# Altium schematic sheet Sheet2.SchDoc
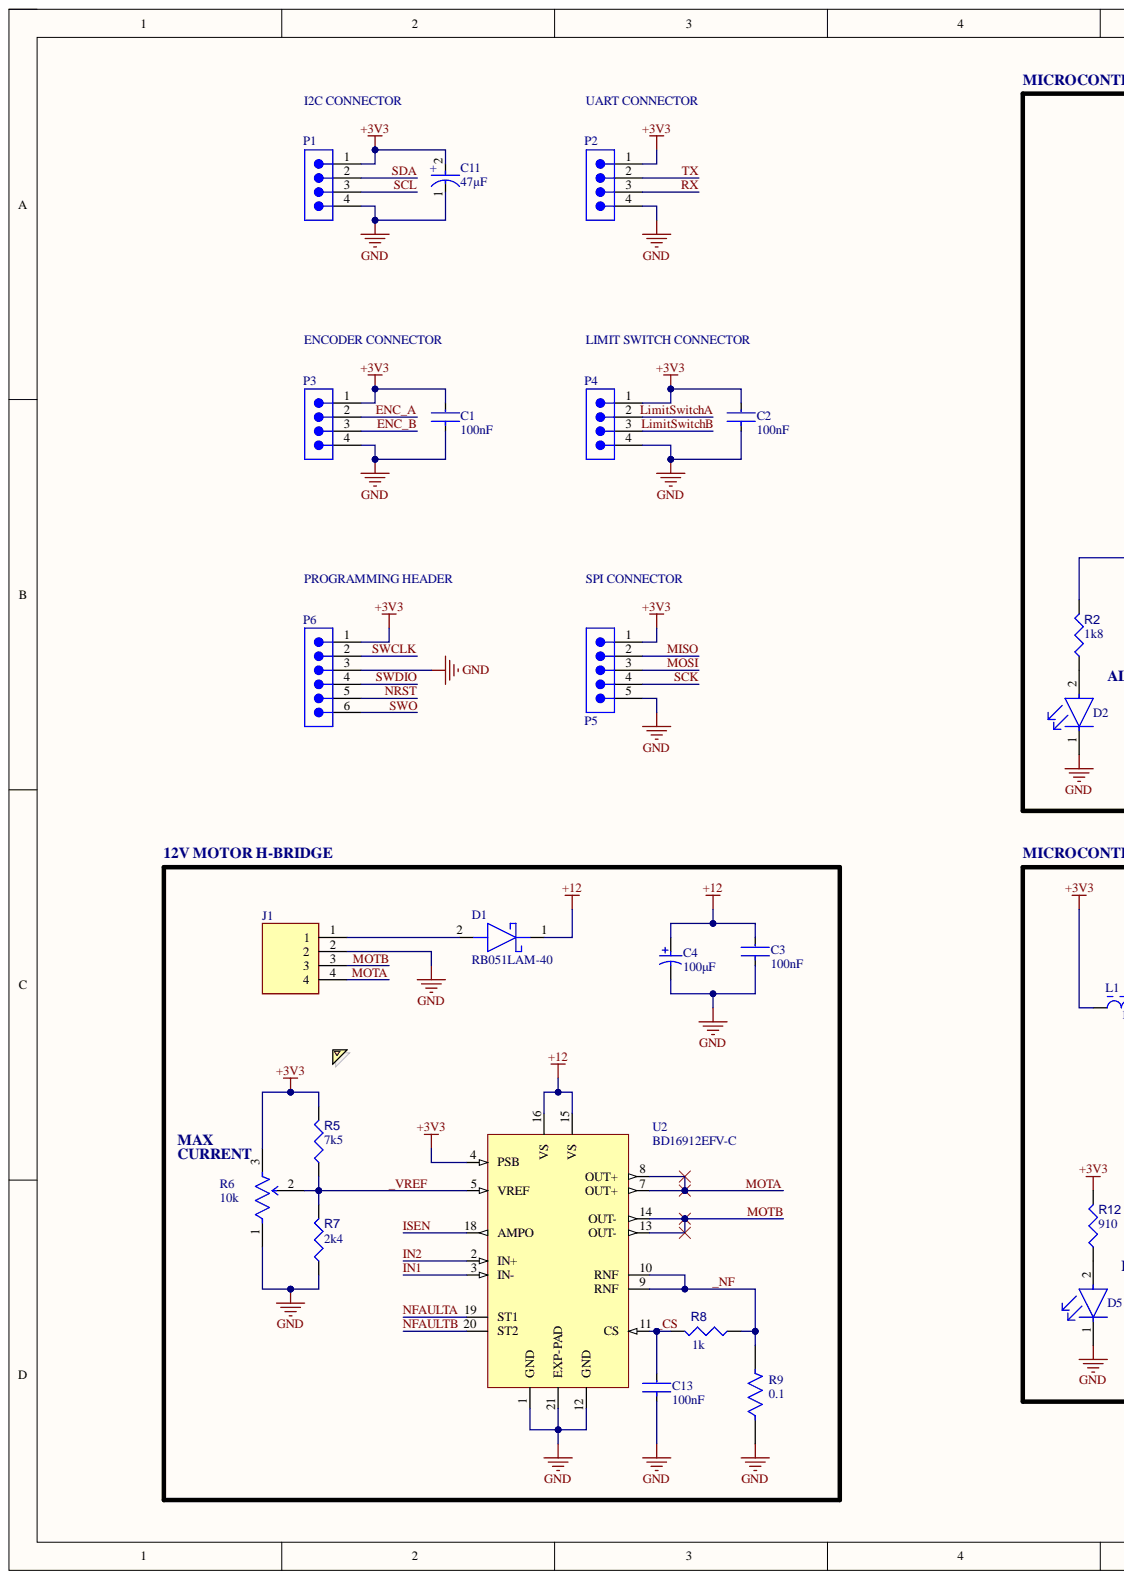
[diagram p0: Sheet2.SchDoc, left]
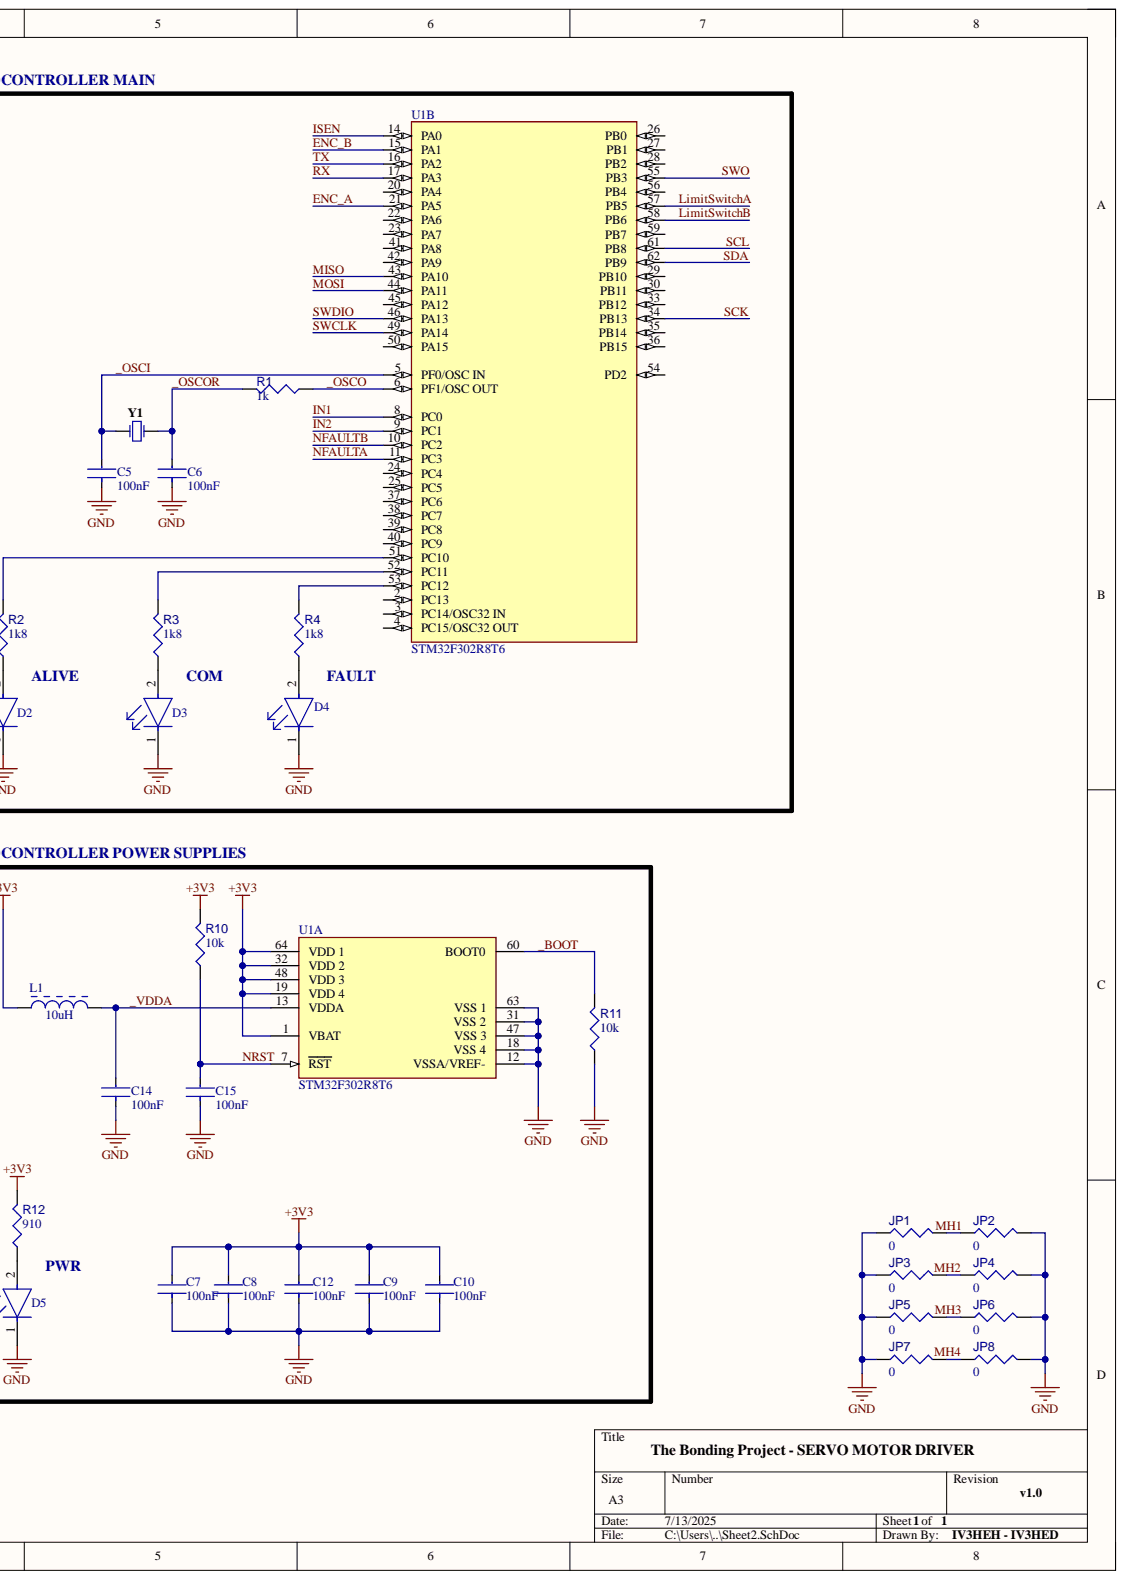
[diagram p1: Sheet2.SchDoc, right]

## Parts
C1  Capacitance: 100nF  [FP-0805-L_2_01_0_2-W_1_25-MFG]  (hidden comment: 08055C104K4T2A)
C2  Capacitance: 100nF  [FP-0805-L_2_01_0_2-W_1_25-MFG]  (hidden comment: 08055C104K4T2A)
C3  Capacitance: 100nF  [FP-0805-L_2_01_0_2-W_1_25-MFG]  (hidden comment: 08055C104K4T2A)
C4  Capacitance: 100μF  [CAPPR60-350-1150X800X1300]  (hidden comment: UPW1V101MPD)
C5  Capacitance: 100nF  [FP-0805-L_2_01_0_2-W_1_25-MFG]  (hidden comment: 08055C104K4T2A)
C6  Capacitance: 100nF  [FP-0805-L_2_01_0_2-W_1_25-MFG]  (hidden comment: 08055C104K4T2A)
C7  Capacitance: 100nF  [FP-0805-L_2_01_0_2-W_1_25-MFG]  (hidden comment: 08055C104K4T2A)
C8  Capacitance: 100nF  [FP-0805-L_2_01_0_2-W_1_25-MFG]  (hidden comment: 08055C104K4T2A)
C9  Capacitance: 100nF  [FP-0805-L_2_01_0_2-W_1_25-MFG]  (hidden comment: 08055C104K4T2A)
C10  Capacitance: 100nF  [FP-0805-L_2_01_0_2-W_1_25-MFG]  (hidden comment: 08055C104K4T2A)
C11  value 47µF  [FP-RAD-TH-D_6_3_0_5-L_7_1-MFG]  (hidden comment: EEA-GA1A470H)
C12  Capacitance: 100nF  [FP-0805-L_2_01_0_2-W_1_25-MFG]  (hidden comment: 08055C104K4T2A)
C13  Capacitance: 100nF  [FP-0805-L_2_01_0_2-W_1_25-MFG]  (hidden comment: 08055C104K4T2A)
C14  Capacitance: 100nF  [FP-0805-L_2_01_0_2-W_1_25-MFG]  (hidden comment: 08055C104K4T2A)
C15  Capacitance: 100nF  [FP-0805-L_2_01_0_2-W_1_25-MFG]  (hidden comment: 08055C104K4T2A)
D1  RB051LAM-40  [RF071LAM4S]
D2  LTST-C171GKT  [FP-LTST-C171GKT-MFG]  (value not drawn)
D3  LTST-C171GKT  [FP-LTST-C171GKT-MFG]  (value not drawn)
D4  LTST-C171GKT  [FP-LTST-C171GKT-MFG]  (value not drawn)
D5  LTST-C171GKT  [FP-LTST-C171GKT-MFG]  (value not drawn)
J1  1711042  [1711042]  (value not drawn)
JP1  value 0  [Copy of RESC0402(1005)_L]  (hidden comment: Jumper 0402)
JP2  value 0  [Copy of RESC0402(1005)_L]  (hidden comment: Jumper 0402)
JP3  value 0  [Copy of RESC0402(1005)_L]  (hidden comment: Jumper 0402)
JP4  value 0  [Copy of RESC0402(1005)_L]  (hidden comment: Jumper 0402)
JP5  value 0  [Copy of RESC0402(1005)_L]  (hidden comment: Jumper 0402)
JP6  value 0  [Copy of RESC0402(1005)_L]  (hidden comment: Jumper 0402)
JP7  value 0  [Copy of RESC0402(1005)_L]  (hidden comment: Jumper 0402)
JP8  value 0  [Copy of RESC0402(1005)_L]  (hidden comment: Jumper 0402)
L1  10uH  [INDC2013X105X50ML20T20]
P1  61300411121  [61300411121]  (value not drawn)
P2  61300411121  [61300411121]  (value not drawn)
P3  61300411121  [61300411121]  (value not drawn)
P4  61300411121  [61300411121]  (value not drawn)
P5  61300511121  [61300511121]  (value not drawn)
P6  61300611121  [61300611121]  (value not drawn)
R1  value 1k  [RESC0805(2012)_L]  (hidden comment: Resistor 1K +/-5% 0805 125 mW)
R2  value 1k8  [RESC0805(2012)_L]  (hidden comment: Resistor 1K +/-5% 0805 125 mW)
R3  value 1k8  [RESC0805(2012)_L]  (hidden comment: Resistor 1K +/-5% 0805 125 mW)
R4  value 1k8  [RESC0805(2012)_L]  (hidden comment: Resistor 1K +/-5% 0805 125 mW)
R5  value 7k5  [RESC0805(2012)_L]  (hidden comment: Resistor 1K +/-5% 0805 125 mW)
R6  Resistance: 10k  [FP-ST-4EB-MFG]  (hidden comment: ST-4ETB204)
R7  value 2k4  [RESC0805(2012)_L]  (hidden comment: Resistor 1K +/-5% 0805 125 mW)
R8  value 1k  [RESC0805(2012)_L]  (hidden comment: Resistor 1K +/-5% 0805 125 mW)
R9  value 0.1  [FP-RL2512-MFG]  (hidden comment: CMP-03412-013102-1)
R10  value 10k  [RESC0805(2012)_L]  (hidden comment: Resistor 1K +/-5% 0805 125 mW)
R11  value 10k  [RESC0805(2012)_L]  (hidden comment: Resistor 1K +/-5% 0805 125 mW)
R12  value 910  [RESC0805(2012)_L]  (hidden comment: Resistor 1K +/-5% 0805 125 mW)
U1  STM32F302R8T6  [FP-LQFP64-5W-IPC_A]  (parts A,B)
U2  BD16912EFV-C  [HTSSOP-B20]
Y1  XRCGB16M000FXN00R0  [XRCGB16M000FXN00R0]  (value not drawn)

## Nets
+3V3: C1.2 C2.2 C7.2 C8.2 C9.2 C10.2 C11.2 C12.2 L1.1 P1.1 P2.1 P3.1 P4.1 P5.1 P6.1 R5.2 R6.3 R10.2 R12.2 U1.1(VBAT) U1.19(VDD_4) U1.32(VDD_2) U1.48(VDD_3) U1.64(VDD_1) U2.4(PSB)
+12: C3.2 C4.1 D1.1 U2.15(VS) U2.16(VS)
GND: C1.1 C2.1 C3.1 C4.2 C5.1 C6.1 C7.1 C8.1 C9.1 C10.1 C11.1 C12.1 C13.1 C14.1 C15.1 D2.1 D3.1 D4.1 D5.1 J1.2 JP1.1 JP2.2 JP3.1 JP4.2 JP5.1 JP6.2 JP7.1 JP8.2 P1.4 P2.4 P3.4 P4.4 P5.5 P6.3 R6.1 R7.1 R9.1 R11.1 U1.12(VSSA/VREF-) U1.18(VSS_4) U1.31(VSS_2) U1.47(VSS_3) U1.63(VSS_1) U2.1(GND) U2.12(GND) U2.21(EXP-PAD)
_BOOT: R11.2 U1.60(BOOT0)
_CS: C13.2 R8.2 U2.11(CS)
_NF: R8.1 R9.2 U2.9(RNF) U2.10(RNF)
_OSCI: C5.2 U1.5(PF0/OSC_IN) Y1.1
_OSCO: R1.1 U1.6(PF1/OSC_OUT)
_OSCOR: C6.2 R1.2 Y1.2
_VDDA: C14.2 L1.2 U1.13(VDDA)
_VREF: R5.1 R6.2 R7.2 U2.5(VREF)
ENC_A: P3.2 U1.21(PA5)
ENC_B: P3.3 U1.15(PA1)
IN1: U1.8(PC0) U2.3(IN-)
IN2: U1.9(PC1) U2.2(IN+)
ISEN: U1.14(PA0) U2.18(AMPO)
LimitSwitchA: P4.2 U1.57(PB5)
LimitSwitchB: P4.3 U1.58(PB6)
MH1: JP1.2 JP2.1
MH2: JP3.2 JP4.1
MH3: JP5.2 JP6.1
MH4: JP7.2 JP8.1
MISO: P5.2 U1.43(PA10)
MOSI: P5.3 U1.44(PA11)
MOTA: J1.4 U2.7(OUT+) U2.8(OUT+)
MOTB: J1.3 U2.13(OUT-) U2.14(OUT-)
NFAULTA: U1.11(PC3) U2.19(ST1)
NFAULTB: U1.10(PC2) U2.20(ST2)
NRST: C15.2 P6.5 R10.1 U1.7(RST)
RX: P2.3 U1.17(PA3)
SCK: P5.4 U1.34(PB13)
SCL: P1.3 U1.61(PB8)
SDA: P1.2 U1.62(PB9)
SWCLK: P6.2 U1.49(PA14)
SWDIO: P6.4 U1.46(PA13)
SWO: P6.6 U1.55(PB3)
TX: P2.2 U1.16(PA2)
NetD1_2: D1.2 J1.1
NetD2_2: D2.2 R2.1
NetD3_2: D3.2 R3.1
NetD4_2: D4.2 R4.1
NetD5_2: D5.2 R12.1
NetR2_2: R2.2 U1.51(PC10)
NetR3_2: R3.2 U1.52(PC11)
NetR4_2: R4.2 U1.53(PC12)

Not connected: U1 2(PC13) 3(PC14/OSC32_IN) 4(PC15/OSC32_OUT) 20(PA4) 22(PA6) 23(PA7) 24(PC4) 25(PC5) 26(PB0) 27(PB1) 28(PB2) 29(PB10) 30(PB11) 33(PB12) 35(PB14) 36(PB15) 37(PC6) 38(PC7) 39(PC8) 40(PC9) 41(PA8) 42(PA9) 45(PA12) 50(PA15) 54(PD2) 56(PB4) 59(PB7)

## Library data of the parts
C1-C3 C5-C10 C12-C15 = CMP-2007-04370-2: Automotive Ceramic Capacitor, 0805, 100nF, 10%, X7R, 15%, 50V; Case Code (Imperial): 0805; Case Code (Metric): 2012; Case/Package: 0805; Component Kind: Standard; Construction: Flat; Contact Plating: Tin; Depth: 1.25mm; Dielectric: X7R; Height: 0.94mm; Lead Free: Lead Free; Length: 2.01mm; Library Name: Altium Content Vault; Max Operating Temperature: 125°C; Min Operating Temperature: -55°C; Mount: Surface Mount; Number of Pins: 2; Package Quantity: 1; Packaging: Tape and Reel; Radiation Hardening: No; Ratings: AEC-Q200; REACH SVHC: No SVHC; RoHS Compliant: Yes; Series: -; Termination: SMD/SMT; Thickness: 0.037inch; Tolerance: 10%; Voltage Rating: 50V; Voltage Rating (DC): 50V; Width: 0.049inch
C4 = CMP-2000-07363-1: Aluminum Electrolytic Capacitor, 100 uF, +/- 20%, 35 V, -55 to 105 degC, 2-Pin THD, RoHS, Bulk; Manufacturer: Nichicon; Brand/Series: UPWSeries; Configuration: Radial,Can; Temperature, Operating, Minimum: -55Deg.C; Tolerance: -20,+20%; Voltage, Rating: 35VDC; Case Size: 0.315In.Dia.x0.453In.L(8mmDia.x11.5mmL); Component Kind: Standard; Datasheet URL: http://nichicon-us.com/english/products/pdfs/e-upw.pdf; Datasheet Version: 08/2015; Lead Spacing: 0.138In.(3.50mm)mm; Library Name: Altium Content Vault; Manufacturer URL: http://www.nichicon.co.jp/english/; Material, Element: AluminumElectrolytic; Mounting Technology: ThroughHole; Mounting Type: PCB; Package Description: 2-Pin Through Hole Device, Dia 8 mm, Body Length 11.5 mm; Package Reference: CAPPR60-350-1150X800X1300; Termination: Through-hole
C11 = CMP-00001-1: Aluminum Electrolytic Capacitor, Polarized, Aluminum (wet), 10V, 20% +Tol, 20% -Tol, 47uF, Through Hole Mount; Capacitor Type: Aluminium; Leakage Current: 4.7uA; Ripple Current: 65mA; Tan Delta: 0.22; Component Kind: Standard; Diameter: 6.3mm; Dielectric Material: Aluminium; JESD-609 Code: e3; Length: 7mm; Max Operating Temperature: 105°C; Min Operating Temperature: -55°C; Mounting Technology: Through Hole; Package Shape: Cylindrical; Package Style: RadialMeter; Packaging: Tape and Reel; Pins: 2; Polarity: Polarized; Rated DC Voltage (URdc): 10V; Reach SVHC Compliant: Yes; RoHS Compliant: Yes; Terminal Finish: Tin; Terminal Pitch: 2.5mm; Termination: Wire; Tolerance  (fill in as +-): 20%; Tolerance (fill in as +-): 20%; Library Name: Massimo Danelutti
D1 = RB051LAM-40: Schottky Diode; Manufacturer_Name: ROHM Semiconductor; Component Kind: Standard; Datasheet Link: https://fscdn.rohm.com/en/products/databook/datasheet/discrete/diode/schottky_barrier/rb051lam-40tr-e.pdf; Height: 0.95mm; Manufacturer_Part_Number: RB051LAM-40; Mouser Part Number: N/A; Mouser Price/Stock: https://www.mouser.co.uk/ProductDetail/ROHM-Semiconductor/RB051LAM-40?qs=W0yvOO0ixfF7GPscPw9n5Q%3D%3D
D2-D5 = CMP-22017-000007-1: LED GREEN CLEAR CHIP SMD; Dominant Wavelength: 569nm; Forward Current: 30mA; Forward Voltage: 2.1V; Lens Color: Colorless; Lens Style: Diffused; Luminous Intensity: 12mcd; Number of Elements: 1; Power Dissipation: 100mW; Reverse Voltage: 5V; Test Current: 10mA; Viewing Angle: 130°; Wavelength: 585nm; Case Code (Imperial): 0805; Case Code (Metric): 2012; Case/Package: 0805; Color: Green; Component Kind: Standard; Depth: 1.25mm; Height: 0.8mm; Illumination Color: Green; Lead Free: Lead Free; Length: 2mm; Lens Transparency: Clear; Max Operating Temperature: 85°C; Min Operating Temperature: -55°C; Mount: Surface Mount; Number of LEDs: 1; Number of Pins: 2; Package Quantity: 1; Packaging: Tape and Reel; Power Rating: 100mW; Radiation Hardening: No; Width: 1.25mm
  D2 D4 D5: Library Name: Altium Content Vault
  D3: Library Name: Bonding_Motor_Driver.IntLib
J1 = 1711042: Connector; Manufacturer_Name: Phoenix Contact; Arrow Part Number: 1711042; Arrow Price/Stock: https://www.arrow.com/en/products/1711042/phoenix-contact?utm_currency=USD&region=nac; Component Kind: Standard; Datasheet Link: http://www.phoenixcontact.com/de/produkte/1711042/pdf; Height: 18.2mm; Library Name: SamacSys.SchLib; Manufacturer_Part_Number: 1711042; Mouser Part Number: 651-1711042; Mouser Price/Stock: https://www.mouser.co.uk/ProductDetail/Phoenix-Contact/1711042?qs=JcjeNNoqGSOUKx8wK4Z%252B%2Fg%3D%3D
JP1-JP8 = CMP-009-00148-4: Case/Package: 0402; Component Kind: Standard; Description: Jumper 0402; Max Operating Temperature: 155°C; Min Operating Temperature: -55°C; Pins: 2; Library Name: Massimo Danelutti
L1 = CMP-006-00000-3: IND, Chip Ferrite Bead, 330 Ohm, +/- 25%, 1.5 A, -55 to 125 degC, 0805 (2012 Metric), Tape and Reel; Manufacturer: Murata; Current Rating: 1.5A; Impedance: 330Ohm; Resistance: 90mohm; Case/Package: 0805; Component Kind: Standard; Core Material: Ferrite; Datasheet URL: http://psearch.en.murata.com/inductor/product/LQG15HS15NJ02_.pdf; Datasheet Version: Nov-11; Max Current Rating: 1.5A; Max Operating Temperature: 125°C; Min Operating Temperature: -55°C; Mounting Technology: SM; Package Description: 2-Pin Surface Mount Device, Body 2 x1.25 mm; Package Quantity: 4000; Package Reference: 0805; Packaging: TapeandReel; RoHS Compliant: True; Tolerance: 25%; Value: 10uH; Library Name: Massimo Danelutti
P1-P4 = CMP-1502-01064-3: NexarPartId: 32855522; Connector Type: Male Pin; Contact Resistance: 20mΩ; Current Rating: 3A; Gender: Male; Number of Contacts: 4; Operating Supply Voltage: 250V; Pitch: 2.54mm; Color: Black; Component Kind: Standard; Contact Material: Copper; Contact Mating Length: 5.9944mm; Contact Plating: Gold; Height: 8.89mm; Lead Free: Lead Free; Lead Pitch: 2.54mm; Library Name: Altium Content Vault; Max Operating Temperature: 105°C; Min Operating Temperature: -40°C; Mount: Through Hole; Number of Pins: 4; Number of Positions: 4; Number of Rows: 1; Orientation: Vertical; Packaging: Bulk; REACH SVHC: Unknown; RoHS: Compliant; Termination: Solder; Voltage Rating: 250V
P5 = CMP-1502-01068-3: NexarPartId: 32855526; Connector Type: Male Pin; Contact Resistance: 20mΩ; Current Rating: 3A; Gender: Male; Number of Contacts: 5; Operating Supply Voltage: 250V; Pitch: 2.54mm; Color: Black; Component Kind: Standard; Contact Material: Copper; Contact Mating Length: 5.9944mm; Contact Plating: Gold; Height: 8.89mm; Lead Free: Lead Free; Lead Pitch: 2.54mm; Library Name: Altium Content Vault; Max Operating Temperature: 105°C; Min Operating Temperature: -40°C; Mount: Through Hole; Number of Pins: 5; Number of Positions: 5; Number of Rows: 1; Orientation: Vertical; Packaging: Bulk; REACH SVHC: Unknown; RoHS: Compliant; Termination: Solder; Voltage Rating: 250V
P6 = CMP-1502-01070-3: NexarPartId: 20084590; Connector Type: Male Pin; Contact Resistance: 20mΩ; Current Rating: 3A; Gender: Male; Number of Contacts: 6; Operating Supply Voltage: 250V; Pitch: 2.54mm; Color: Black; Component Kind: Standard; Contact Gender: Male; Contact Material: Copper; Contact Mating Length: 5.9944mm; Contact Plating: Gold; Height: 8.89mm; Housing Material: PBT; Lead Free: Lead Free; Lead Pitch: 2.54mm; Library Name: Altium Content Vault; Max Operating Temperature: 105°C; Min Operating Temperature: -40°C; Mount: Through Hole; Number of Pins: 6; Number of Positions: 6; Number of Rows: 1; Orientation: Vertical; Packaging: Bulk; REACH SVHC: Unknown; RoHS: Compliant; Termination: Through Hole; Voltage Rating: 250V
R1-R5 R7 R8 R10-R12 = CMP-009-00118-3: Chip Resistor, 1 kOhms, +/-5%, 125 mW, -55 to 155 degC, 0805; Power: 125mW; Tolerance: 5%; Case/Package: 0805; Component Kind: Standard; Max Operating Temperature: 155°C; Min Operating Temperature: -55°C; Pins: 2; Library Name: Massimo Danelutti
R6 = CMP-71554-000002-1: TRIMMER 200K OHM 0.25W GW TOP; Max Power Dissipation: 250mW; Number of Turns: 1; Temperature Coefficient: 100ppm/°C; Tolerance: 20%; Color: Black; Component Kind: Standard; Composition: Cermet; Height: 0.091inch; Lead Free: Lead Free; Length: 0.197inch; Library Name: Altium Content Vault; Max Operating Temperature: 125°C; Min Operating Temperature: -55°C; Mount: Surface Mount; Package Quantity: 1; Packaging: Tape and Reel; Radiation Hardening: No; Termination: Gull Wing; Weight: 120mg; Width: 0.177inch
R9 = CMP-009-00181-1: RES 0.1 OHM 1% 1W 2512; Manufacturer: YAGEO; Manufacturer Part Number: RL2512FK-070R1L; Automotive Grade: Grade 0; Temperature Coefficient: 600ppm/°C; Automotive: Yes; Case/Package: RL2512; Category: Res; Component Kind: Standard; Component Type: Standard; Composition: Thick Film; Design Item ID: CMP-03412-013102-1; Device Class L1: Passive Components; Device Class L2: Resistors; Device Class L3: Chip SMD Resistors; Digikey Description: RES 0.1 OHM 1% 1W 2512; Footprint Url: http://www.yageo.com/exep/pages/download/literatures/PYu-R_Mount_10.pdf; Height: 0.65mm; Ipc Land Pattern Name: RESC635320X55; Lead Free: Yes; Mouser Description: Current Sense Resistors 0.1ohm 1% 1W; Octopart: https://octopart.com/rl2512fk-070r1l-yageo-42334369; Pins: 2; Power Rating: 1W; RoHS: TRUE; Series: RL; Source: Components - 01.05.25 - 15.35.SchLib; Temperature: -55°C to +155°C; Temperature Range High: 155°C; Temperature Range Low: -55°C; Tolerance: 1%; Library Name: Massimo Danelutti
U1 = CMP-0537-00018-2: IC MCU 32BIT 64KB FLASH 64LQFP; Core Architecture: ARM; Data Bus Width: 32b; Frequency: 72MHz; Interface: I2C; Max Power Dissipation: 444mW; Max Supply Voltage: 3.6V; Min Supply Voltage: 2V; Number of ADC Channels: 11; Number of I/Os: 51; Number of Timers/Counters: 9; Oscillator Type: Internal; Peripherals: POR; RAM Size: 16KB; Watchdog Timer: Yes; Case/Package: LQFP; Component Kind: Standard; Library Name: Altium Content Vault; Max Frequency: 72MHz; Max Operating Temperature: 85°C; Memory Size: 64KB; Memory Type: FLASH; Min Operating Temperature: -40°C; Number of Pins: 64; Number of Programmable I/O: 51; Package Quantity: 960; Packaging: Tray; Radiation Hardening: No; REACH SVHC: No SVHC; RoHS Compliant: Yes; Weight: 0.012088oz
U2 = CMP-006-000000-3: Motor/Actuator Driver DC Brush Motor Series  40V/3A Rating H Bridge Driver; Mfr_Name: Unknown; Component Kind: Standard; Design Item ID: BD16912EFV-C; RefDes: RefDes; Source: Unknown.SchLib; Type: Type; Library Name: Massimo Danelutti
Y1 = CMP-003-00030-2: Crystal (Frequency: 16.0000MHz / Size: 2.0 x 1.6mm); ESR: 200; Frequency: 16MHz; Load Capacitance: 6pF; Case/Package: -; Component Kind: Standard; Max Operating Temperature: 85°C; Min Operating Temperature: -30°C; Mounting Technology: SMD; Pins: 2; Library Name: Massimo Danelutti
